# Altium schematic sheet p07_DapLink_OB-RA4M2.SchDoc
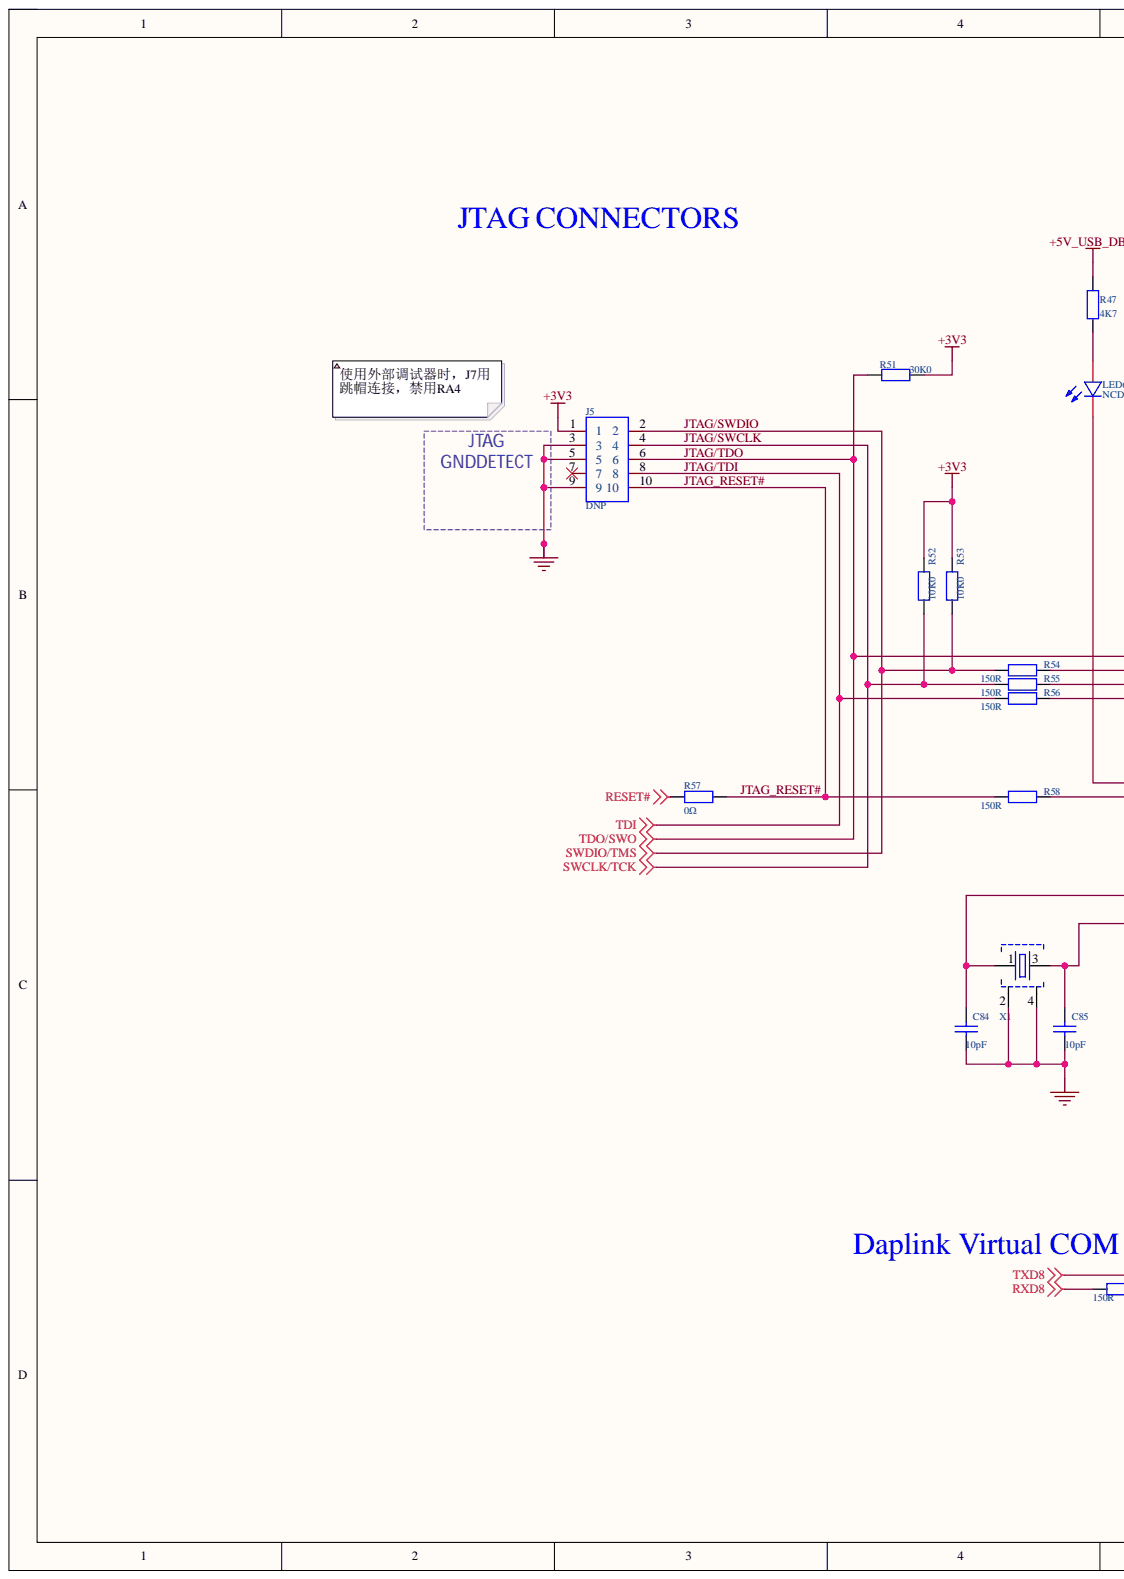
[diagram p0: p07_DapLink_OB-RA4M2.SchDoc, left]
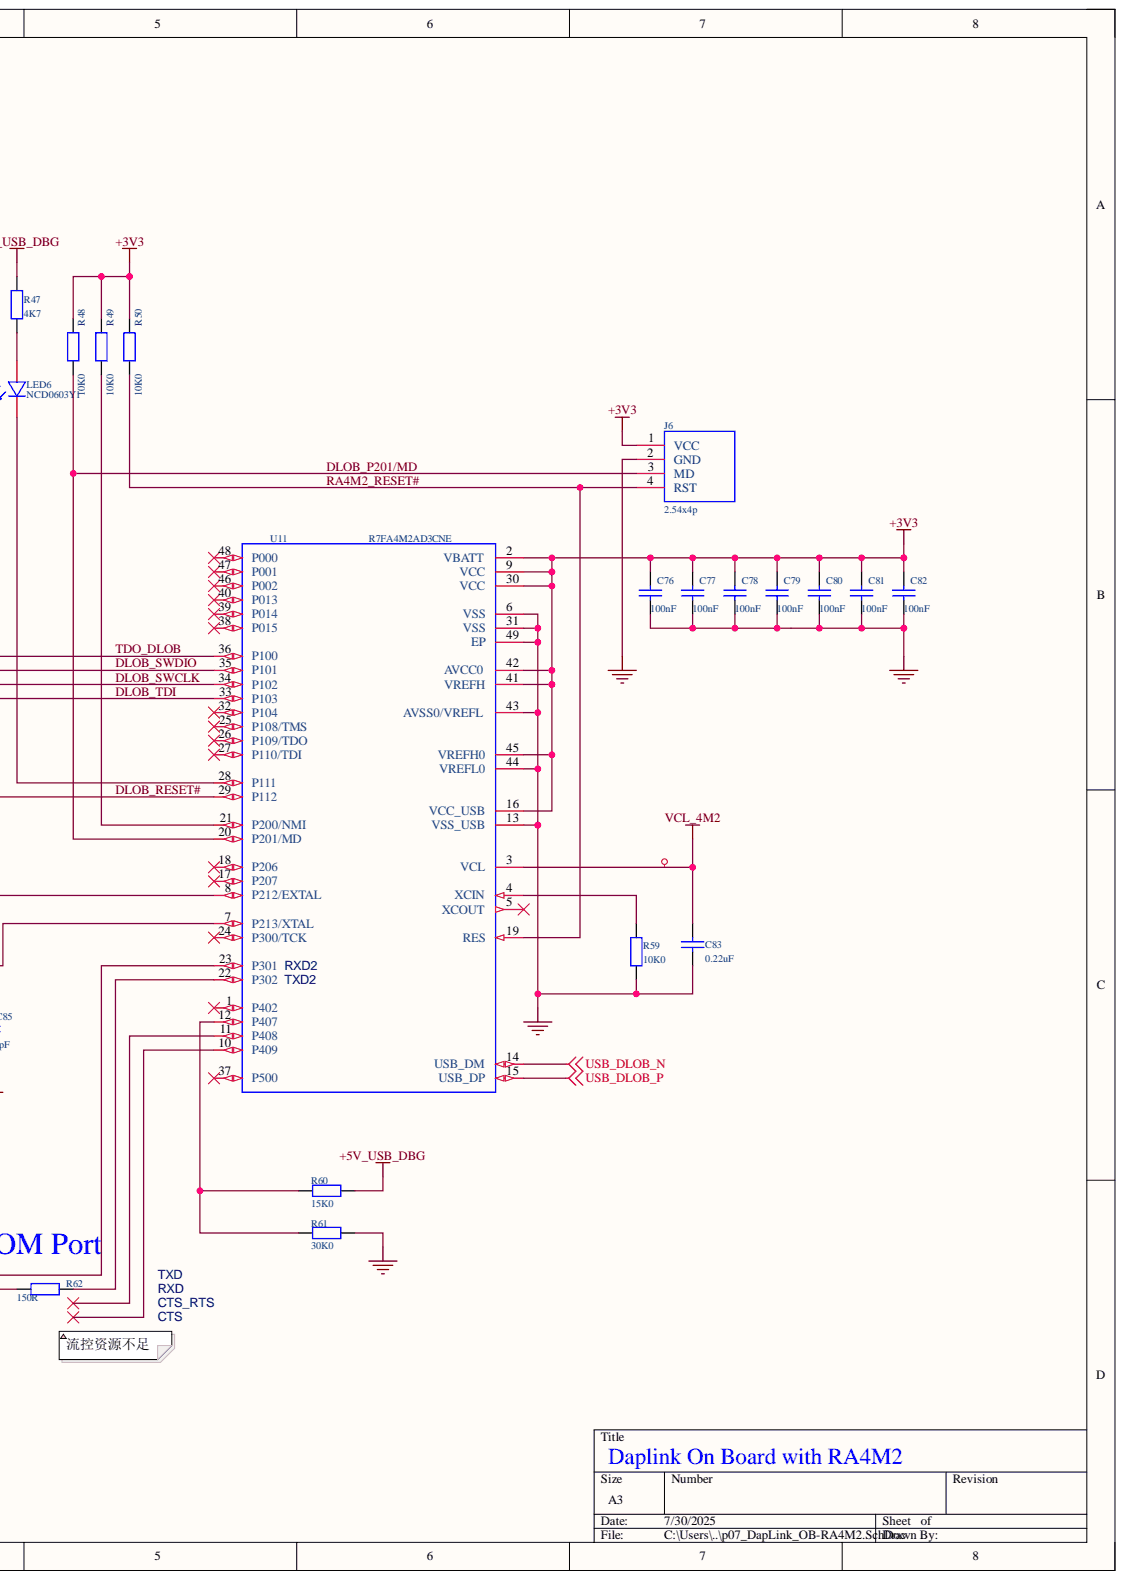
[diagram p1: p07_DapLink_OB-RA4M2.SchDoc, right]

## Parts
C76  Capacitance: 100nF  [C0201]  (hidden comment: 100 nf (0.1uF) 16V)
C77  Capacitance: 100nF  [C0201]  (hidden comment: 100 nf (0.1uF) 16V)
C78  Capacitance: 100nF  [C0201]  (hidden comment: 100 nf (0.1uF) 16V)
C79  Capacitance: 100nF  [C0201]  (hidden comment: 100 nf (0.1uF) 16V)
C80  Capacitance: 100nF  [C0201]  (hidden comment: 100 nf (0.1uF) 16V)
C81  Capacitance: 100nF  [C0201]  (hidden comment: 100 nf (0.1uF) 16V)
C82  Capacitance: 100nF  [C0201]  (hidden comment: 100 nf (0.1uF) 16V)
C83  Capacitance: 0.22uF  [C0402]  (hidden comment: 0.22uF 25V)
C84  10pF  [C0402]
C85  10pF  [C0402]
J5  DNP  [HDR-SMD_10P-P1.27-V-M-R2-C5-LS5.5_1]
J6  2.54x4p  [dingzhen]
LED6  NCD0603Y1  [LED0603-RD]
R47  Resistance: 4K7  [R0402]  (hidden comment: 4.7K)
R48  10K0  [R0402]
R49  10K0  [R0402]
R50  10K0  [R0402]
R51  30K0  [R0402]
R52  10K0  [R0402]
R53  10K0  [R0402]
R54  150R  [R0402]
R55  150R  [R0402]
R56  150R  [R0402]
R57  0Ω  [R0402]
R58  150R  [R0402]
R59  10K0  [R0402]
R60  15K0  [R0402]
R61  30K0  [R0402]
R62  150R  [R0402]
U11  R7FA4M2AD3CNE  [QFN-48_L7.0-W7.0-P0.50-BL-EP5.5]
X1  X252012MMB4SI-24  [SMD_2520]  (value not drawn)

## Nets
+3V3: C76.2 C77.2 C78.2 C79.2 C80.2 C81.2 C82.2 J5.1 J6.1(VCC) R48.2 R49.2 R50.2 R51.2 R52.2 R53.2 U11.2(VBATT) U11.9(VCC) U11.16(VCC_USB) U11.30(VCC) U11.41(VREFH) U11.42(AVCC0) U11.45(VREFH0)
+5V_USB_DBG: R47.1 R60.2
GND: C76.1 C77.1 C78.1 C79.1 C80.1 C81.1 C82.1 C83.1 C84.1 C85.1 J5.3 J5.5 J5.9 J6.2(GND) R59.1 R61.2 U11.6(VSS) U11.13(VSS_USB) U11.31(VSS) U11.43(AVSS0/VREFL) U11.44(VREFL0) U11.49(EP) X1.2 X1.4
RESET#: R57.1
RXD8: R62.1
TXD8: U11.23(P301)
USB_DLOB_N: U11.14(USB_DM)
USB_DLOB_P: U11.15(USB_DP)
VCL_4M2: C83.2 U11.3(VCL)
DLOB_P201/MD: J6.3(MD) R48.1 U11.20(P201/MD)
DLOB_RESET#: R58.2 U11.29(P112)
DLOB_SWCLK: R55.2 U11.34(P102)
DLOB_SWDIO: R54.2 U11.35(P101)
DLOB_TDI: R56.2 U11.33(P103)
JTAG/SWCLK: J5.4 R52.1 R55.1
JTAG/SWDIO: J5.2 R53.1 R54.1
JTAG/TDI: J5.8 R56.1
JTAG/TDO: J5.6 R51.1 U11.36(P100)
JTAG_RESET#: J5.10 R57.2 R58.1
RA4M2_RESET#: J6.4(RST) R50.1 U11.19(RES)
NetC84_2: C84.2 U11.8(P212/EXTAL) X1.1
NetC85_2: C85.2 U11.7(P213/XTAL) X1.3
NetLED6_1: LED6.1 U11.28(P111)
NetLED6_2: LED6.2 R47.2
NetR49_1: R49.1 U11.21(P200/NMI)
NetR59_2: R59.2 U11.4(XCIN)
NetR60_1: R60.1 R61.1 U11.12(P407)
NetR62_2: R62.2 U11.22(P302)

Not connected: J5 7; U11 1(P402) 5(XCOUT) 10(P409) 11(P408) 17(P207) 18(P206) 24(P300/TCK) 25(P108/TMS) 26(P109/TDO) 27(P110/TDI) 32(P104) 37(P500) 38(P015) 39(P014) 40(P013) 46(P002) 47(P001) 48(P000)

## Library data of the parts
C76 = CAP-000030-01: Ceramic Chip Capacitor 0201 100 nf (0.1uF) 16V; Legacy Part Number: 151-10004022; Manufacturer: Yageo; Manufacturer Part Number 1: CC0402KRX7R8BB104; Dialectric: X7R; Notes: ANY EQUIVALENT; Tolerance: 10%; ClassName: JLOB; Component Kind: Standard; Library Name: Capacitors.IntLib; Manufacturer 1: Yageo; Manufacturer 2: Kemet; Manufacturer 3: AVX; Manufacturer Part Number 2: C0402C104K4RACTU; Manufacturer Part Number 3: 0402YC104KAT2A; Mfg: Yageo; Mfg P/N: CC0402KRX7R8BB104; Mfg Part Number: CC0402KRX7R8BB104; Package: 0402; PN: CC0402KRX7R8BB104; Supplier 1: Arrow Electronics; Supplier 2: Digi-Key; Supplier 3: Newark; Supplier 4: Mouser; Supplier Part Number 1: CC0402KRX7R8BB104; Supplier Part Number 2: 311-2077-1-ND; Supplier Part Number 3: 40AJ6370; Supplier Part Number 4: 603-CC402KRX7R8BB104; Voltage: 16V
C77-C82 = CAP-000030-01: Ceramic Chip Capacitor 0402 100 nf (0.1uF) 16V; Legacy Part Number: 151-10004022; Manufacturer: Yageo; Manufacturer Part Number 1: CC0402KRX7R8BB104; Dialectric: X7R; Notes: ANY EQUIVALENT; Tolerance: 10%; ClassName: JLOB; Component Kind: Standard; Library Name: Capacitors.IntLib; Manufacturer 1: Yageo; Manufacturer 2: Kemet; Manufacturer 3: AVX; Manufacturer Part Number 2: C0402C104K4RACTU; Manufacturer Part Number 3: 0402YC104KAT2A; Mfg: Yageo; Mfg P/N: CC0402KRX7R8BB104; Mfg Part Number: CC0402KRX7R8BB104; Package: 0402; PN: CC0402KRX7R8BB104; Supplier 1: Arrow Electronics; Supplier 2: Digi-Key; Supplier 3: Newark; Supplier 4: Mouser; Supplier Part Number 1: CC0402KRX7R8BB104; Supplier Part Number 2: 311-2077-1-ND; Supplier Part Number 3: 40AJ6370; Supplier Part Number 4: 603-CC402KRX7R8BB104; Voltage: 16V
C83 = CAP-000120-01: Ceramic Chip Capacitor 0402 0.22uF 25V; Manufacturer: Samsung; Manufacturer Part Number 1: CL05A224KA5NNNC; Dialectric: X5R; Notes: ANY EQUIVALENT; Tolerance: 10%; ClassName: JLOB; Component Kind: Standard; Library Name: Capacitors.IntLib; Manufacturer 1: Samsung; Mfg: Samsung; Mfg Part Number: CL05A224KA5NNNC; Package: 0402; PN: CL05A224KA5NNNC; Supplier 1: Digi-Key; Supplier Part Number 1: 1276-1455-1-ND; Voltage: 25V
C84 C85 = CAP-100118-01: Ceramic Chip Capacitor 0402 10pF 50V; Legacy Part Number: 151-10005153; Manufacturer: Yageo; Manufacturer Part Number 1: CC0402JRNPO9BN100; Dialectric: COG/NPO; Notes: ANY EQUIVALENT; Tolerance: 5%; Capacitance: 10pF; ClassName: JLOB; Component Kind: Standard; Manufacturer 1: Yageo; Mfg: Yageo; Mfg P/N: CC0402JRNPO9BN100; Mfg Part Number: CC0402JRNPO9BN100; Package: 0402; PN: CC0402JRNPO9BN100; Supplier 1: Digi-Key; Supplier Part Number 1: 311-1014-1-ND; Voltage: 50V
  C84: Library Name: ra8p1_rt.SchLib
  C85: Library Name: Capacitors.IntLib
J5 = HX PZ1.27-2x5P TP: Manufacturer: hanxia(韩下); Add into BOM: yes; Circluar Pins/Square Pins: 方针; Current Rating: 1A; Pin Structure: 2x5P; Pitch: 1.27mm; Color: 黑色; Component Kind: Standard; Contact Material: 黄铜; Contact Plating: 金; Convert to PCB: yes; Datasheet: https://atta.szlcsc.com/upload/public/pdf/source/20240830/6C2540B6182E5928E8E33F1671F88B22.pdf; Description: HX PZ1.27-2x5P TP; Device: HX PZ1.27-2x5P TP; Insulation Height: 1mm; JLCPCB Part Class: Extended Part; LCSC Part Name: 2x5P 间距:1.27mm 方针 立贴; Length of End Connection Pin: -; Length of Mating Pin: 4mm; Library Name: ra8p1_v0.2.SCHLIB; Manufacturer Part: HX PZ1.27-2x5P TP; Mounting Type: 立贴; Number of Pins: 10P; Number of Rows: 双排; Operating Temperature: -40℃~+105℃; PCB3D: HDR-SMD_10P-P1.27-V-M-R2-C5-LS5.5_1; Row Spacing: 1.27mm; Supplementary Features: -; Supplier: LCSC; Supplier Footprint: SMD,P=1.27mm; Supplier Part: C41376037; Symbol: HX PZ1.27-2X5P TP; Voltage Rating (Max): -
J6 = dingzhen: 探针触点，4p; Component Kind: Standard; Library Name: ra8p1_v0.2.SCHLIB
LED6 = LED0603YELLOW: YELLOW,0603; Manufacturer: 国星光电; Manufacturer Part: NCD0603R1; Add into BOM: yes; Device: NCD0603R1_C84263; Diode Configuration: 独立二极管; Forward Current: 25mA; Illumination Color: 红色; Luminous Intensity: 80mcd~220mcd; Power(Watts): 65mW; Symbol: FC-DA1608HRK-620D; Viewing Angle: 130°; Voltage - Forward(Vf): 1.6V~2.6V; Wavelength - Dominant: 615nm~630nm; Color Temperature: -; Component Kind: Standard; Convert to PCB: yes; Datasheet: https://atta.szlcsc.com/upload/public/pdf/source/20200820/C84263_DABC6A75E58F576973B407FDBA283D60.pdf; JLCPCB Part Class: Extended Part; Lamp Holder Type: -; LCSC Part Name: NCD0603R1; Lens Color: -; Library Name: ra8p1_v0.1.SCHLIB; Mounting Sytle: -; Operating Temperature: -30℃~+85℃; PCB3D: LED0603-RD_RED; Peak Wavelength: -; Supplier: LCSC; Supplier Footprint: 0603; Supplier Part: C84263
R47 = RES-100119-01: Chip Resistor Thick Film 0402 4.7K ohm 1% 1/16W; Legacy Part Number: 150-00000094; Manufacturer: Yageo; Manufacturer Part Number 1: RC0402FR-074K7L; Notes: ANY EQUIVALENT; ClassName: JLOB; Component Kind: Standard; Library Name: Resistors.IntLib; Manufacturer 1: Yageo; Mfg: Yageo; Mfg P/N: RC0402FR-074K7L; Mfg Part Number: RC0402FR-074K7L; Package: 0402; PN: RC0402FR-074K7L; Power: 1/16W; Supplier 1: Future Electronics; Supplier 2: Digi-Key; Supplier 3: Mouser; Supplier 4: Farnell; Supplier Part Number 1: 3795218; Supplier Part Number 2: 311-4.7KLRCT-ND; Supplier Part Number 3: 603-RC0402FR-074K7L; Supplier Part Number 4: 9239316; Tolerance: 1%
R48-R50 R52 R53 R59 = RES-100099-01: Chip Resistor Thick Film 0402 10K0 ohm 1% 1/16W; Manufacturer: Yageo; Manufacturer Part Number 1: RC0402FR-0710KP; Notes: ANY EQUIVALENT; ClassName: JLOB; Component Kind: Standard; Library Name: Resistors.IntLib; Manufacturer 1: Yageo; Manufacturer 2: Samsung Electro-Mechanics; Manufacturer 3: Stackpole Electronics Inc.; Manufacturer Part Number 2: RC1005F103CS; Manufacturer Part Number 3: RMCF0402FT10K0; Mfg: Yageo; Mfg Part Number: RC0402FR-0710KP; Package: 0402; PN: RC0402FR-0710KP; Power: 1/16W; Resistance: 10K0; Supplier 1: Digi-Key; Supplier 2: Digi-Key; Supplier 3: Digi-Key; Supplier Part Number 1: YAG1249CT-ND; Supplier Part Number 2: 1276-3431-1-ND; Supplier Part Number 3: RMCF0402FT10K0CT-ND; Tolerance: 1%
R51 R61 = RES-000201-01: Chip Resistor Thick Film 0402 30K0 1% 1/10W; Manufacturer: Panasonic; Manufacturer Part Number 1: ERJ-2RKF3002X; Notes: ANY EQUIVALENT; ClassName: JLOB; Component Kind: Standard; Library Name: Resistors.IntLib; Manufacturer 1: Panasonic; Mfg: Panasonic; Mfg Part Number: ERJ-2RKF3002X; Package: 0402; PN: ERJ-2RKF3002X; Power: 1/10W; Resistance: 30K0; Simulation: R402_30K0; Supplier 1: Digi-Key; Supplier Part Number 1: P30.0KLCT-ND; Tolerance: 1%; Value: 30K0
R54-R56 R58 R62 = RES-000220-01: Chip Resistor Thick Film 0402 150R 1% 1/10W; Manufacturer: Panasonic; Manufacturer Part Number 1: ERJ-2RKF1500X; Notes: ANY EQUIVALENT; ClassName: JLOB; Component Kind: Standard; Library Name: Resistors.IntLib; Manufacturer 1: Panasonic; Mfg Part Number: ERJ-2RKF1500X; Package: 0402; Power: 1/10W; Resistance: 150R; Supplier 1: Digi-Key; Supplier Part Number 1: P150LCT-ND; Tolerance: 1%
R57 = 0402 0Ω (0R0) 1%: 贴片电阻; Component Kind: Standard; ComponentLink1Description: 供应商链接; ComponentLink1URL: http://www.szlcsc.com/product/details_17853.html; Library Name: ra8p1_v0.1.SCHLIB; Package: 0402; Supplier: LC; SuppliersPartNumber: C17168
R60 = RES-000200-01: Chip Resistor Thick Film 0402 15K0 1% 1/10W; Manufacturer: Panasonic; Manufacturer Part Number 1: ERJ-2RKF1502X; Notes: ANY EQUIVALENT; ClassName: JLOB; Component Kind: Standard; Library Name: ra8p1_rt.SchLib; Manufacturer 1: Panasonic; Mfg: Panasonic; Mfg Part Number: ERJ-2RKF1502X; Package: 0402; PN: ERJ-2RKF1502X; Power: 1/10W; Resistance: 15K0; Simulation: R0402_15K0; Supplier 1: Mouser; Supplier 2: Digi-Key; Supplier 3: Farnell; Supplier Part Number 1: 667-ERJ-2RKF1502X; Supplier Part Number 2: P15.0KLCT-ND; Supplier Part Number 3: 2302754; Tolerance: 1%; Value: 15K0
U11 = R7FA4M2AD3CNE: Renesas RA4M2 Programmed, J-Link On Board (19 May 2022 version) 32-bit 100MHz MCU QFN-48 pin; Legacy Part Number: 100-10008527; Architecture: 32bits; Code Flash: 512KB; Data Flash: 8KB; Notes: MUST USE SPECIFIC ?? FREE ISSUE ??; SRAM: 128KB; ClassName: JLOB; Component Kind: Standard; Display Name: RA4M2; Library Name: ra8p1_v0.1.SCHLIB; Manufacturer: Renesas; Manufacturer 1: Renesas; Manufacturer Part Number 1: R7FA4M2AD3CNE; Max Clock Speed: 100 MHz; Mfg: Renesas; Mfg Part Number: R7FA4M2AD3CNE; Package: QFN-48; PN: R7FA4M2AD3CNE
X1 = X252012MMB4SI-24: 12MHz ±10ppm 10pF,80ohom ESR; Legacy Part Number: 170-10007251; Manufacturer: ECS Inc.; Manufacturer Part Number 1: ECS-120-10-36B-CWY-TR; Frequency: 12.000MHz; Notes: MUST USE SPECIFIC; ClassName: JLOB; Component Kind: Standard; ComponentLink1Description: New link; ComponentLink1URL: https://item.szlcsc.com/3139287.html?fromZone=l_b__%2522brand%2522&spm=sc.bidn.xh9.zy.n___sc.bidn.xh1.zy.p&lcsc_vid=QwANAgdTElRYBVwHQQILVgAAQwJZVlVSFlZXBVEEQlMxVlNSTldcVVJWQlhcXztW; Freq Stability: 10 ppm; Library Name: ra8p1_v0.2.SCHLIB; Load Capacitance: 10pF; Manufacturer 1: ECS Inc.; Mfg: ECS Inc.; Mfg Part Number: ECS-120-10-36B-CWY-TR; PN: ECS-120-10-36B-CWY-TR; Supplier 1: Arrow Electronics; Supplier 2: Avnet; Supplier 3: Digi-Key; Supplier 4: Mouser; Supplier Part Number 1: ECS-120-10-36B-CWY-TR; Supplier Part Number 2: ECS-120-10-36B-CWY-TR; Supplier Part Number 3: XC3046CT-ND; Supplier Part Number 4: 520-120-10-36B-CWYT
